# Altium schematic sheet Incubator.SchDoc
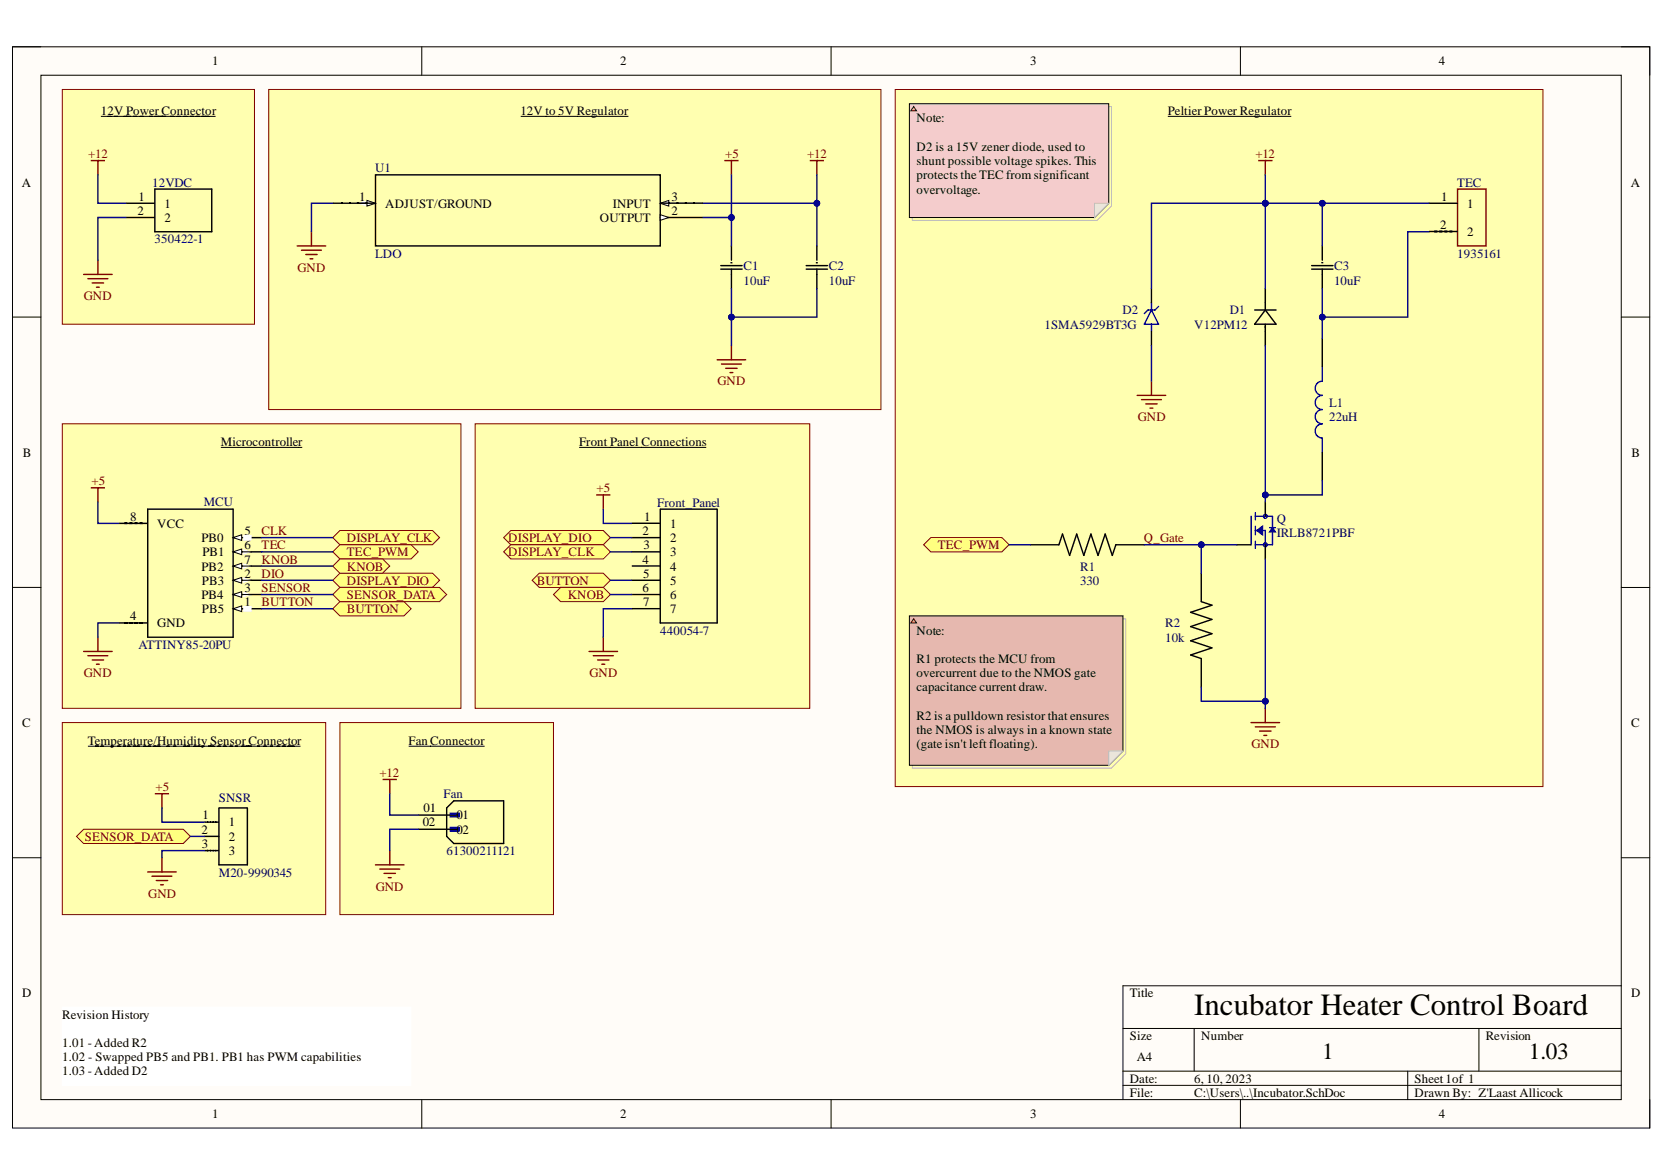
[diagram p0: Incubator.SchDoc]

## Parts
12VDC  350422-1  [*TE_350422-1]
C1  10uF  [CAP_CL31_SAM]
C2  10uF  [CAP_CL31_SAM]
C3  10uF  [CAP_CL31_SAM]
D1  V12PM12  [CR_M12-M3/86A_VIS]
D2  1SMA5929BT3G  [DIOM5226X220N]
Fan  61300211121  [*WURTH_61300211121_61300211121(Primary)]
Front_Panel  440054-7  [*TE_440054-7]
L1  22uH  [IND_AMPLA1707S-220MT]
MCU  ATTINY85-20PU  [*DIP762W46P254L927H533Q8B]
Q  IRLB8721PBF  [TO254P1067X483X2057-3]
R1  330  [*RESC3116X65N]
R2  10k  [*RESC3116X65N]
SNSR  M20-9990345  [*HDRV3W64P254_1X3_762X254X910P]
TEC  1935161  [PHOENIX_1935161]
U1  LDO  [DPAK229P991X238-3N]

## Ports
BUTTON, DISPLAY_CLK, DISPLAY_DIO, KNOB, SENSOR_DATA, TEC_PWM

## Nets
+5: C1.2 Front_Panel.1 MCU.8 SNSR.1 U1.2
+12: 12VDC.1 C2.2 C3.2 D1.1 D2.C Fan.01 TEC.1 U1.3
GND: 12VDC.2 C1.1 C2.1 D2.A Fan.02 Front_Panel.7 MCU.4 Q.3 R2.1 SNSR.3 U1.1
BUTTON: Front_Panel.5 MCU.1
CLK: Front_Panel.2 MCU.5
DIO: Front_Panel.3 MCU.2
KNOB: Front_Panel.6 MCU.7
Q_Gate: Q.1 R1.2 R2.2
SENSOR: MCU.3 SNSR.2
TEC: MCU.6 R1.1
NetC3_1: C3.1 L1.2 TEC.2
NetD1_2: D1.2 L1.1 Q.2
NetFront_Panel_4: Front_Panel.4

## Library data of the parts
C1-C3 = CL31F106ZPHNNNE: No Description Available; Mfr_Name: Samsung; Type: DEV; Component Kind: Standard; Digi-Key_Part_Number_1: 1276-1853-2-ND; Digi-Key_Part_Number_2: 1276-1853-1-ND; Digi-Key_Part_Number_3: 1276-1853-6-ND; Library Name: CL31F106ZPHNNNE.IntLib; Manufacturer_Part_Number: CL31F106ZPHNNNE; RefDes: RefDes; Copyright: Copyright (C) 2023 Ultra Librarian. All rights reserved.
D1 = V12PM12-M3/86A: No Description Available; Mfr_Name: Vishay; Component Kind: Standard; Digi-Key_Part_Number_1: V12PM12-M3/86AGITR-ND; Digi-Key_Part_Number_2: V12PM12-M3/86AGICT-ND; Digi-Key_Part_Number_3: V12PM12-M3/86AGIDKR-ND; Library Name: V12PM12-M3_86A.IntLib; Manufacturer_Part_Number: V12PM12-M3/86A; Copyright: Copyright (C) 2023 Ultra Librarian. All rights reserved.
D2 = 1SMA5929BT3G: Zener Diode 15V 1.5W Â±5% Surface Mount SMA; MF: ON Semiconductor; Availability: Good; Check_prices: https://www.snapeda.com/parts/1SMA5929BT3G/ON%20Semiconductor/view-part/426691/?ref=eda; Component Kind: Standard; Library Name: 1SMA5929BT3G.IntLib; MP: 1SMA5929BT3G; Package: SMA-2 ON Semiconductor; Price: None; Purchase-URL: https://pricing.snapeda.com/search/part/1SMA5929BT3G/?ref=eda; SnapEDA_Link: https://www.snapeda.com/parts/1SMA5929BT3G/ON%20Semiconductor/view-part/426691/?ref=snap
L1 = AMPLA1707S-220MT: Inductor Power Shielded/Molded Wirewound 22uH 20% 100KHz Carbonyl Powder 12A 0.0265Ohm DCR T/R; MF: Abracon Corporation; Check_prices: https://www.snapeda.com/parts/AMPLA1707S-220MT/Abracon/view-part/?ref=eda; Component Kind: Standard; Digi-Key_Part_Number: 535-AMPLA1707S-220MTTR-ND; Library Name: AMPLA1707S-220MT.IntLib; MP: AMPLA1707S-220MT; Package: None; Purchase-URL: https://pricing.snapeda.com/search/part/AMPLA1707S-220MT/?ref=eda; SnapEDA_Link: https://www.snapeda.com/parts/AMPLA1707S-220MT/Abracon/view-part/?ref=snap
Q = IRLB8721PBF: Very Low RDS_on_ at 4.5V VGS | Ultra-Low Gate Impedance | Fully Characterized Avalanche Voltage and Current | Lead-Free; MF: Infineon Technologies; Availability: Unavailable; Component Kind: Standard; Library Name: IRLB8721PBF.IntLib; MP: IRLB8721PBF; Package: TO-220 Infineon; Price: None; SnapEDA_Link: https://www.snapeda.com/parts/IRLB8721PBF/Infineon%20Technologies/view-part/1849062/?ref=snap
R1 R2 = RC1206JR-07330RL: Check_prices: https://www.snapeda.com/parts/RC1206JR-07330RL/Yageo/view-part/?ref=eda; Component Kind: Standard; Library Name: RC1206JR-07330RL.IntLib; SnapEDA_Link: https://www.snapeda.com/parts/RC1206JR-07330RL/Yageo/view-part/?ref=snap
TEC = 1935161: 2 Position Wire to Board Terminal Block Horizontal with Board 0.197 (5.00mm) Through Hole; MF: Phoenix Contact; Availability: In Stock; Check_prices: https://www.snapeda.com/parts/1935161/Phoenix+Contact/view-part/?ref=eda; Component Kind: Standard; Library Name: 1935161.IntLib; MP: 1935161; Package: None; Price: None; Purchase-URL: https://pricing.snapeda.com/search/part/1935161/?ref=eda; SnapEDA_Link: https://www.snapeda.com/parts/1935161/Phoenix+Contact/view-part/?ref=snap
U1 = NCP1117DT50RKG: No Description Available; Mfr_Name: onsemi; Component Kind: Standard; Digi-Key_Part_Number_1: 2156-NCP1117DT50RKG-OS-ND; Digi-Key_Part_Number_3: NCP1117DT50RKGOSCT-ND; Digi-Key_Part_Number_4: NCP1117DT50RKGOSDKR-ND; Digi-Key_Part_Number_5: NCP1117DT50RKGOSTR-ND; Library Name: NCP1117DT50RKG.IntLib; Manufacturer_Part_Number: NCP1117DT50RKG; RefDes: RefDes; Type: DEV; Copyright: Copyright (C) 2023 Ultra Librarian. All rights reserved.
12VDC = 350422-1: Check_prices: https://www.snapeda.com/parts/350422-1/TE+Connectivity+AMP+Connectors/view-part/?ref=eda; Component Kind: Standard; Library Name: 350422-1.IntLib; SnapEDA_Link: https://www.snapeda.com/parts/350422-1/TE+Connectivity+AMP+Connectors/view-part/?ref=snap
Fan = 61300211121: Check_prices: https://www.snapeda.com/parts/61300211121/Wurth+Elektronik/view-part/?ref=eda; Component Kind: Standard; Library Name: 61300211121.IntLib; SnapEDA_Link: https://www.snapeda.com/parts/61300211121/Wurth+Elektronik/view-part/?ref=snap
Front_Panel = 440054-7: Check_prices: https://www.snapeda.com/parts/440054-7/TE+Connectivity/view-part/?ref=eda; Component Kind: Standard; Library Name: 440054-7.IntLib; SnapEDA_Link: https://www.snapeda.com/parts/440054-7/TE+Connectivity/view-part/?ref=snap
MCU = ATTINY85-20PU: Check_prices: https://www.snapeda.com/parts/ATTINY85-20PU/Microchip/view-part/?ref=eda; Component Kind: Standard; Library Name: ATTINY85-20PU.IntLib; SnapEDA_Link: https://www.snapeda.com/parts/ATTINY85-20PU/Microchip/view-part/?ref=snap
SNSR = M20-9990345: Check_prices: https://www.snapeda.com/parts/M20-9990345/Harwin+Inc./view-part/?ref=eda; Component Kind: Standard; Library Name: M20-9990345.IntLib; SnapEDA_Link: https://www.snapeda.com/parts/M20-9990345/Harwin+Inc./view-part/?ref=snap
